# Altium schematic sheet Memory.SchDoc(Memory)
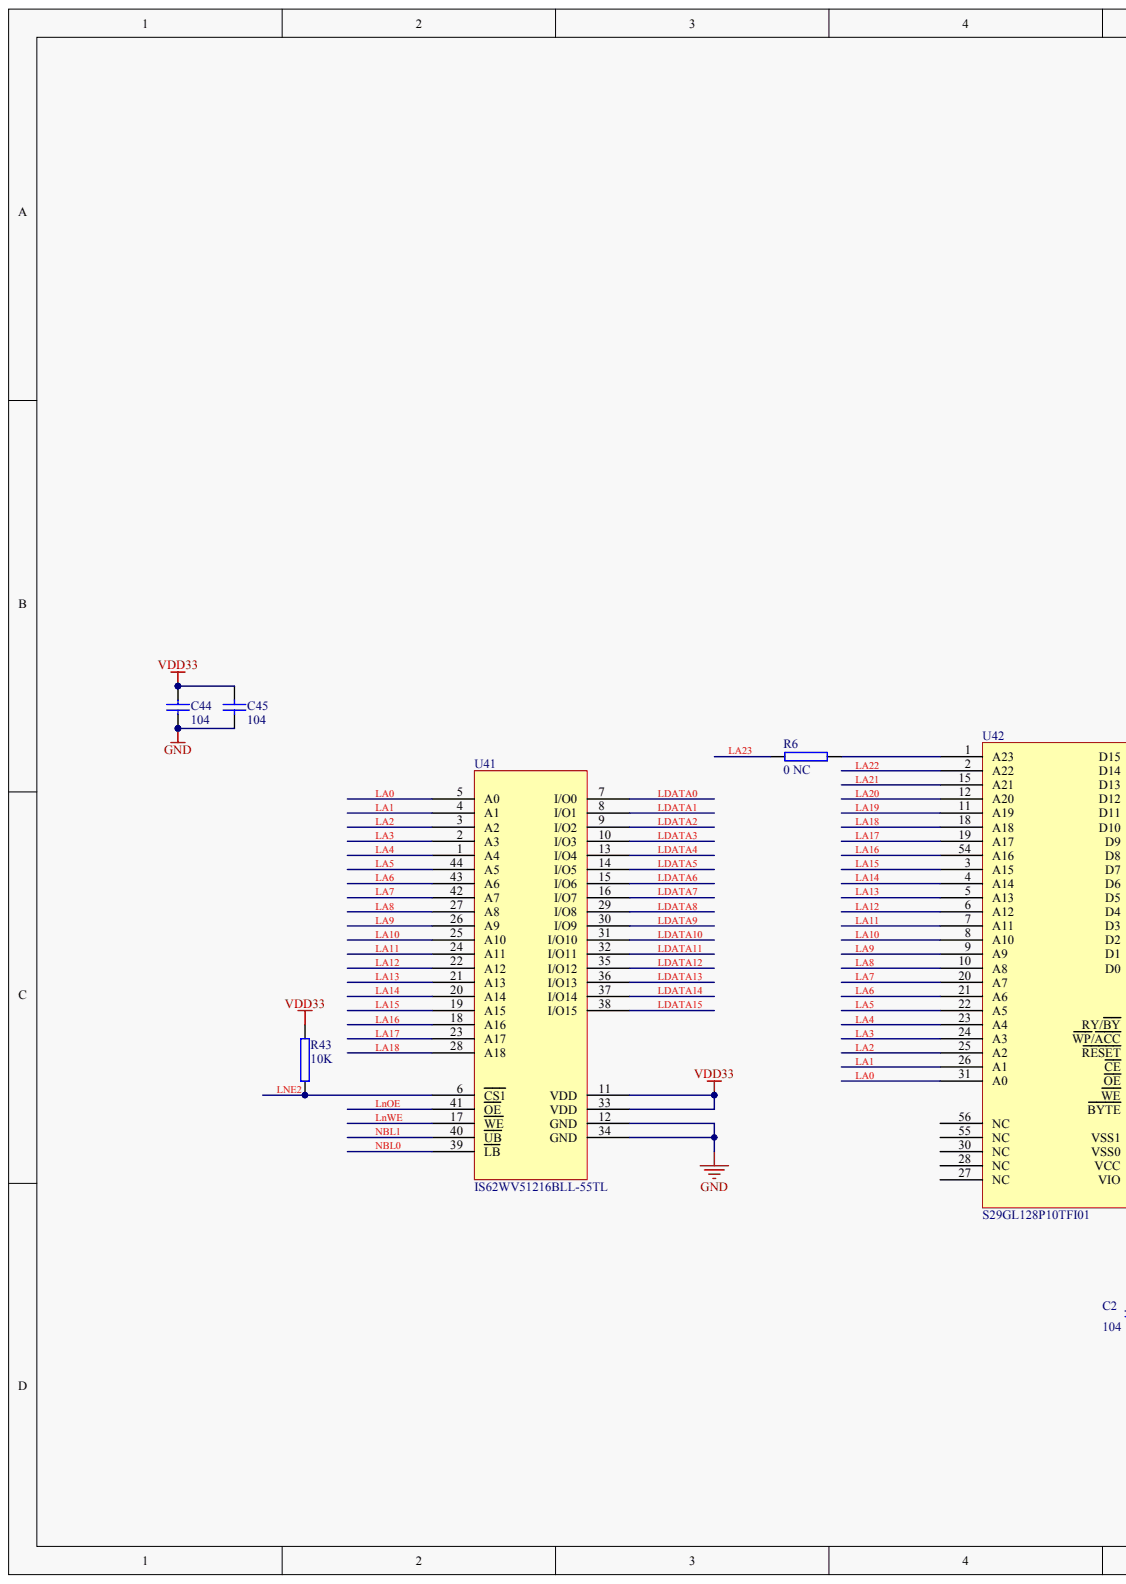
[diagram p0: Memory.SchDoc, left]
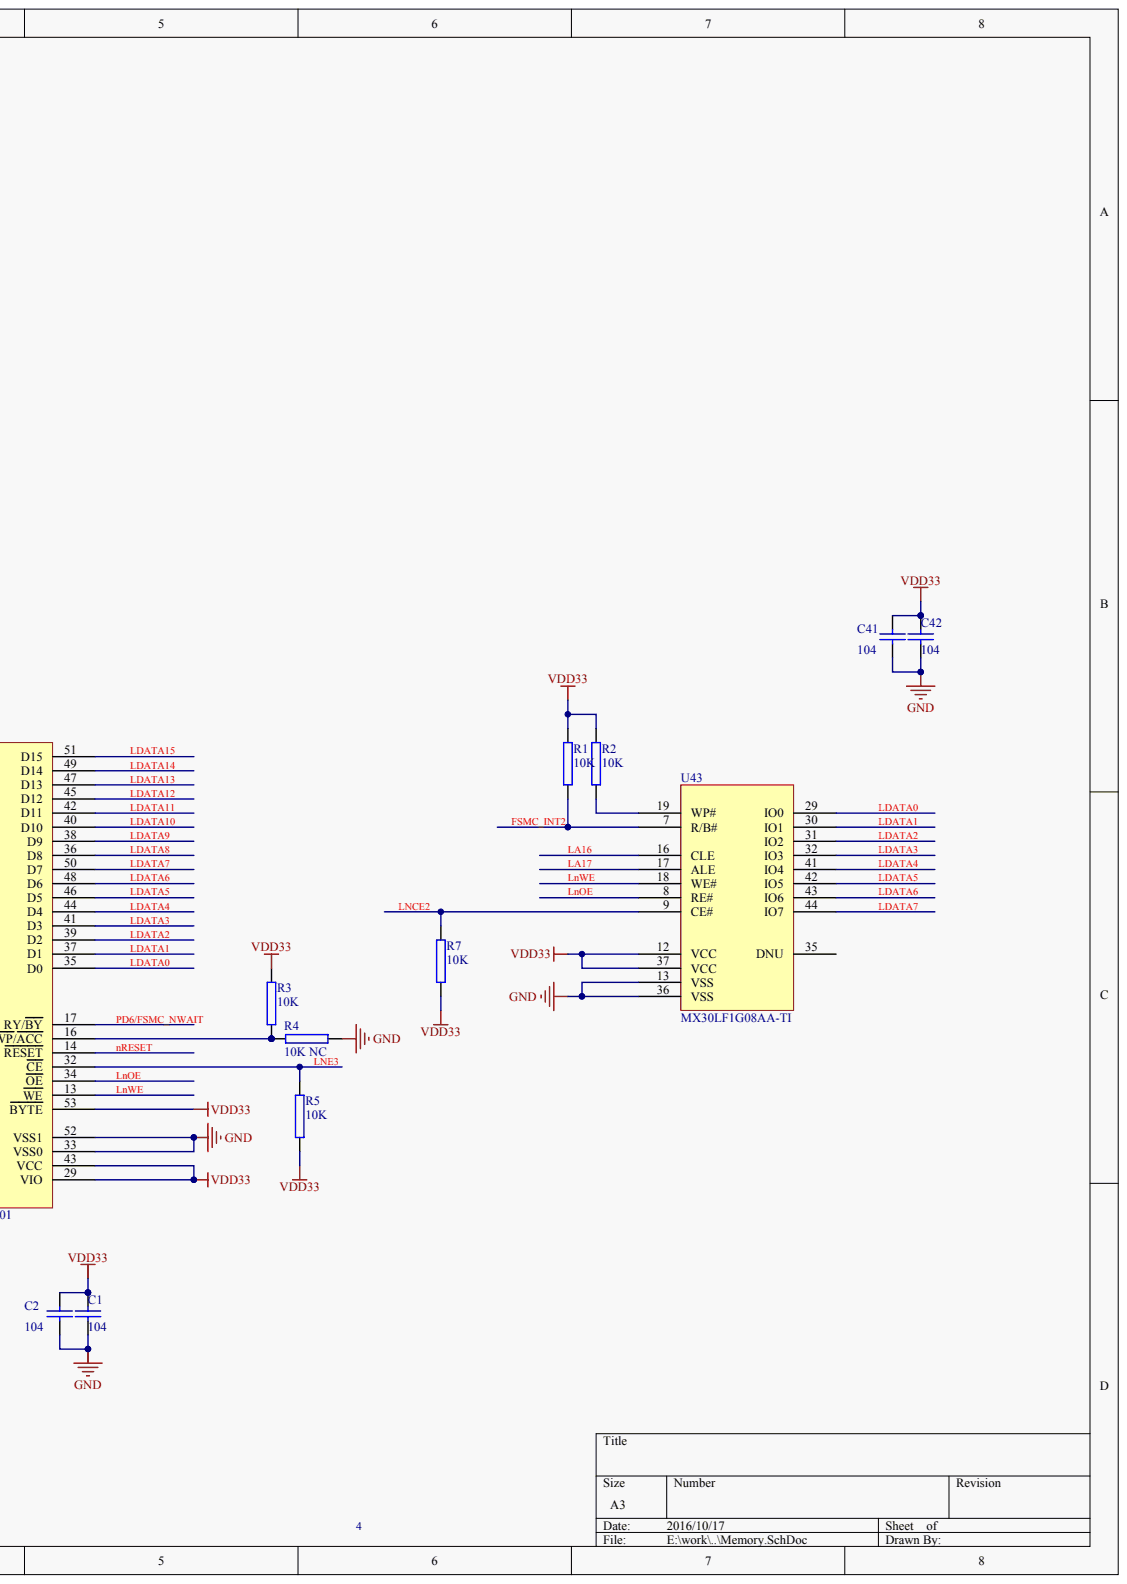
[diagram p1: Memory.SchDoc, right]

## Parts
C1  104
C2  104
C41  104
C42  104
C44  104
C45  104
R1  10K
R2  10K
R3  10K
R4  10K  value 10K NC
R5  10K
R6  value 0 NC  (hidden comment: 10K)
R7  10K
R43  10K
U41  IS62WV51216BLL-55TL
U42  S29GL128P10TFI01
U43  MX30LF1G08AA-TI

## Nets
GND: C1.2 C2.2 C41.2 C42.2 C44.1 C45.1 R4.1 U41.12(GND) U41.34(GND) U42.33(VSS0) U42.52(VSS1) U43.13(VSS) U43.36(VSS)
VDD33: C1.1 C2.1 C41.1 C42.1 C44.2 C45.2 R1.2 R2.2 R3.2 R5.1 R7.2 R43.2 U41.11(VDD) U41.33(VDD) U42.29(VIO) U42.43(VCC) U42.53(BYTE) U43.12(VCC) U43.37(VCC)
FSMC_INT2: R1.1 U43.7(R/B#)
LA0: U41.5(A0) U42.31(A0)
LA1: U41.4(A1) U42.26(A1)
LA2: U41.3(A2) U42.25(A2)
LA3: U41.2(A3) U42.24(A3)
LA4: U41.1(A4) U42.23(A4)
LA5: U41.44(A5) U42.22(A5)
LA6: U41.43(A6) U42.21(A6)
LA7: U41.42(A7) U42.20(A7)
LA8: U41.27(A8) U42.10(A8)
LA9: U41.26(A9) U42.9(A9)
LA10: U41.25(A10) U42.8(A10)
LA11: U41.24(A11) U42.7(A11)
LA12: U41.22(A12) U42.6(A12)
LA13: U41.21(A13) U42.5(A13)
LA14: U41.20(A14) U42.4(A14)
LA15: U41.19(A15) U42.3(A15)
LA16: U41.18(A16) U42.54(A16) U43.16(CLE)
LA17: U41.23(A17) U42.19(A17) U43.17(ALE)
LA18: U41.28(A18) U42.18(A18)
LA19: U42.11(A19)
LA20: U42.12(A20)
LA21: U42.15(A21)
LA22: U42.2(A22)
LA23: R6.2
LDATA0: U41.7(I/O0) U42.35(D0) U43.29(IO0)
LDATA1: U41.8(I/O1) U42.37(D1) U43.30(IO1)
LDATA2: U41.9(I/O2) U42.39(D2) U43.31(IO2)
LDATA3: U41.10(I/O3) U42.41(D3) U43.32(IO3)
LDATA4: U41.13(I/O4) U42.44(D4) U43.41(IO4)
LDATA5: U41.14(I/O5) U42.46(D5) U43.42(IO5)
LDATA6: U41.15(I/O6) U42.48(D6) U43.43(IO6)
LDATA7: U41.16(I/O7) U42.50(D7) U43.44(IO7)
LDATA8: U41.29(I/O8) U42.36(D8)
LDATA9: U41.30(I/O9) U42.38(D9)
LDATA10: U41.31(I/O10) U42.40(D10)
LDATA11: U41.32(I/O11) U42.42(D11)
LDATA12: U41.35(I/O12) U42.45(D12)
LDATA13: U41.36(I/O13) U42.47(D13)
LDATA14: U41.37(I/O14) U42.49(D14)
LDATA15: U41.38(I/O15) U42.51(D15)
LNCE2: R7.1 U43.9(CE#)
LNE2: R43.1 U41.6(CS1)
LNE3: R5.2 U42.32(CE)
LNOE: U41.41(OE) U42.34(OE) U43.8(RE#)
LNWE: U41.17(WE) U42.13(WE) U43.18(WE#)
NBL0: U41.39(LB)
NBL1: U41.40(UB)
NRESET: U42.14(RESET)
PD6/FSMC_NWAIT: U42.17(RY/BY)
NetR2_1: R2.1 U43.19(WP#)
NetR3_1: R3.1 R4.2 U42.16(WP/ACC)
NetR6_1: R6.1 U42.1(A23)

Not connected: U42 27(NC) 28(NC) 30(NC) 55(NC) 56(NC); U43 35(DNU)

## Library data of the parts
C1 C2 C41 C42 C44 C45 R1-R7 R43 U41-U43 = (no symbol name)
  C44 C45: Published: 8-Jun-2000; LatestRevisionDate: 17-Jul-2002; LatestRevisionNote: Re-released for DXP Platform.; Value: 104; Publisher: Altium Limited
  R1-R3 R5 R7 R43: Value: 10K
